# Altium schematic sheet 05_DATA_IO.SchDoc(05_DATA_IO)
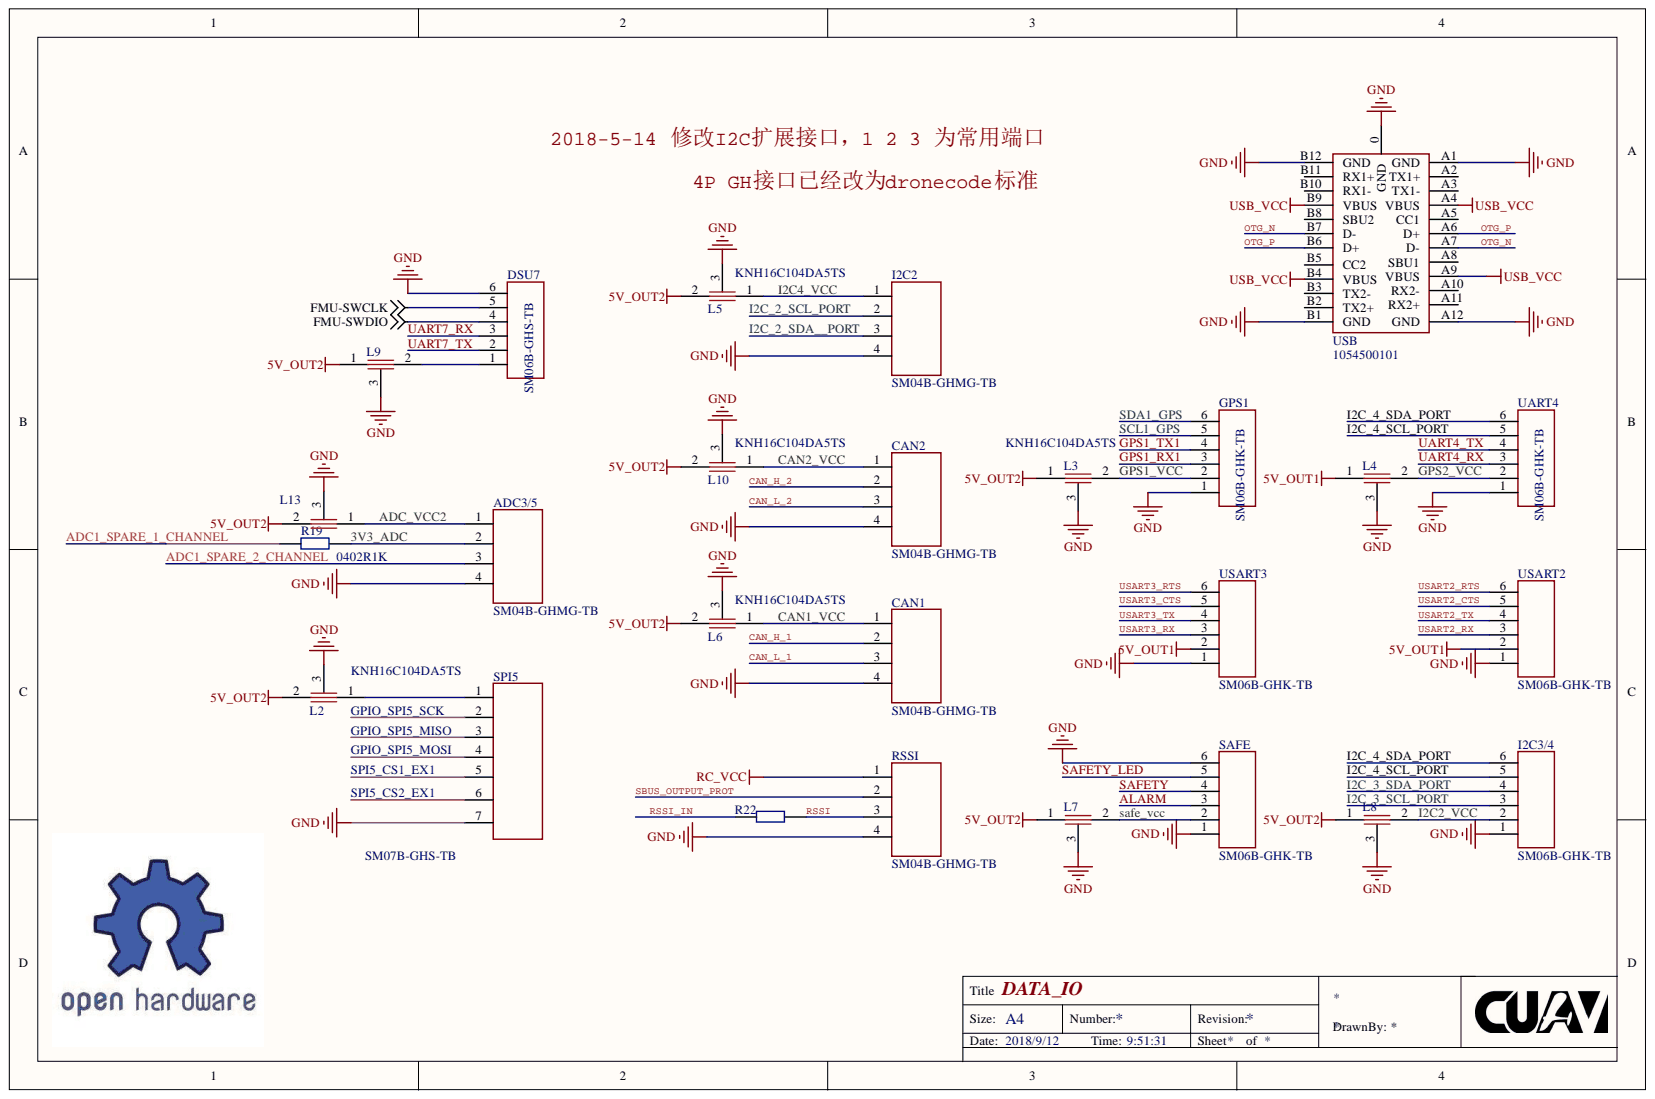
[diagram p0: 05_DATA_IO.SchDoc]

## Parts
ADC3/5  SM04B-GHMG-TB  [SM04B-GHS-DC]
CAN1  SM04B-GHMG-TB  [SM04B-GHS-DC]
CAN2  SM04B-GHMG-TB  [SM04B-GHS-DC]
DSU7  SM06B-GHS-TB  [SM06B-GHS-DC]
GPS1  SM06B-GHK-TB  [SM06B-GHS-TB]
I2C2  SM04B-GHMG-TB  [SM04B-GHS-DC]
I2C3/4  SM06B-GHK-TB  [SM06B-GHS-TB]
L2  KNH16C104DA5TS  [KNH16]
L3  KNH16C104DA5TS  [KNH16]
L4  KNH16C104DA5TS  [KNH16]
L5  KNH16C104DA5TS  [KNH16]
L6  KNH16C104DA5TS  [KNH16]
L7  KNH16C104DA5TS  [KNH16]
L8  KNH16C104DA5TS  [KNH16]
L9  KNH16C104DA5TS  [KNH16]
L10  KNH16C104DA5TS  [KNH16]
L13  KNH16C104DA5TS  [KNH16]
R19  0402R1K  [0402R]
R22  0402R100R  [0402R]  (value not drawn)
RSSI  SM04B-GHMG-TB  [SM04B-GHS-DC]
SAFE  SM06B-GHK-TB  [SM06B-GHS-TB]
SPI5  SM07B-GHS-TB  [SM07B-GHS_DC]
UART4  SM06B-GHK-TB  [SM06B-GHS-TB]
USART2  SM06B-GHK-TB  [SM06B-GHS-TB]
USART3  SM06B-GHK-TB  [SM06B-GHS-TB]
USB  1054500101  [1054500101]

## Nets
5V_OUT1: L4.1 USART2.2 USART3.2
5V_OUT2: L2.2 L3.1 L5.2 L6.2 L7.1 L8.1 L9.1 L10.2 L13.2
FMU-SWCLK: DSU7.5
FMU-SWDIO: DSU7.4
GND: ADC3/5.4 CAN1.4 CAN2.4 DSU7.6 GPS1.1 I2C2.4 I2C3/4.1 L2.3 L3.3 L4.3 L5.3 L6.3 L7.3 L8.3 L9.3 L10.3 L13.3 RSSI.4 SAFE.1 SAFE.6 SPI5.7 UART4.1 USART2.1 USART3.1 USB.0(GND) USB.A1(GND) USB.A12(GND) USB.B1(GND) USB.B12(GND)
RC_VCC: RSSI.1
USB_VCC: USB.A4(VBUS) USB.A9(VBUS) USB.B4(VBUS) USB.B9(VBUS)
3V3_ADC: ADC3/5.2 R19.2
ADC1_SPARE_1_CHANNEL: R19.1
ADC1_SPARE_2_CHANNEL: ADC3/5.3
ADC_VCC2: ADC3/5.1 L13.1
ALARM: SAFE.3
CAN1_VCC: CAN1.1 L6.1
CAN2_VCC: CAN2.1 L10.1
CAN_H_1: CAN1.2
CAN_H_2: CAN2.2
CAN_L_1: CAN1.3
CAN_L_2: CAN2.3
GPIO_SPI5_MISO: SPI5.3
GPIO_SPI5_MOSI: SPI5.4
GPIO_SPI5_SCK: SPI5.2
GPS1_RX1: GPS1.3
GPS1_TX1: GPS1.4
GPS1_VCC: GPS1.2 L3.2
GPS2_VCC: L4.2 UART4.2
I2C2_VCC: I2C3/4.2 L8.2
I2C4_VCC: I2C2.1 L5.1
I2C_2_SCL_PORT: I2C2.2
I2C_2_SDA__PORT: I2C2.3
I2C_3_SCL_PORT: I2C3/4.3
I2C_3_SDA_PORT: I2C3/4.4
I2C_4_SCL_PORT: I2C3/4.5 UART4.5
I2C_4_SDA_PORT: I2C3/4.6 UART4.6
OTG_N: USB.A7(D-) USB.B7(D-)
OTG_P: USB.A6(D+) USB.B6(D+)
RSSI: R22.2 RSSI.3
RSSI_IN: R22.1
safe_vcc: L7.2 SAFE.2
SAFETY: SAFE.4
SAFETY_LED: SAFE.5
SBUS_OUTPUT_PROT: RSSI.2
SCL1_GPS: GPS1.5
SDA1_GPS: GPS1.6
SPI5_CS1_EX1: SPI5.5
SPI5_CS2_EX1: SPI5.6
UART4_RX: UART4.3
UART4_TX: UART4.4
UART7_RX: DSU7.3
UART7_TX: DSU7.2
USART2_CTS: USART2.5
USART2_RTS: USART2.6
USART2_RX: USART2.3
USART2_TX: USART2.4
USART3_CTS: USART3.5
USART3_RTS: USART3.6
USART3_RX: USART3.3
USART3_TX: USART3.4
NetDSU7_1: DSU7.1 L9.2
NetL2_1: L2.1 SPI5.1
4P GH接口已经改为dronecode标准:
2018-5-14 修改I2C扩展接口，1 2 3 为常用端口:

Not connected: USB A2(TX1+) A3(TX1-) A5(CC1) A8(SBU1) A10(RX2-) A11(RX2+) B2(TX2+) B3(TX2-) B5(CC2) B8(SBU2) B10(RX1-) B11(RX1+)

## Library data of the parts
ADC3/5 = SM04B-GHS-TB: Component Kind: Standard; Library Name: CUAV.SchLib
CAN1 CAN2 I2C2 RSSI = SM04B-GHS-TB: dronecode; Component Kind: Standard; Library Name: CUAV.SchLib
DSU7 = SM06B-GHS-TB: dronecode; Component Kind: Standard; Library Name: CUAV.SchLib
GPS1 I2C3/4 SAFE UART4 USART2 USART3 = SM06B-GHS-TB: Component Kind: Standard; Library Name: CUAV.SchLib
R19 = 0402R1K: 0402R1K 1%; Component Kind: Standard; Library Name: CUAV.SchLib
R22 = 0402R100R: 0402R100R 1%; Component Kind: Standard; Library Name: CUAV.SchLib
L2-L10 L13 = KNH16C104DA5TS: Component Kind: Standard; Library Name: CUAV.SchLib
SPI5 = SM07B-GHS-TB: Component Kind: Standard; Library Name: CUAV.SchLib
USB = 1054500101: Component Kind: Standard; Library Name: CUAV.SchLib
